# Altium schematic sheet stm32.SchDoc
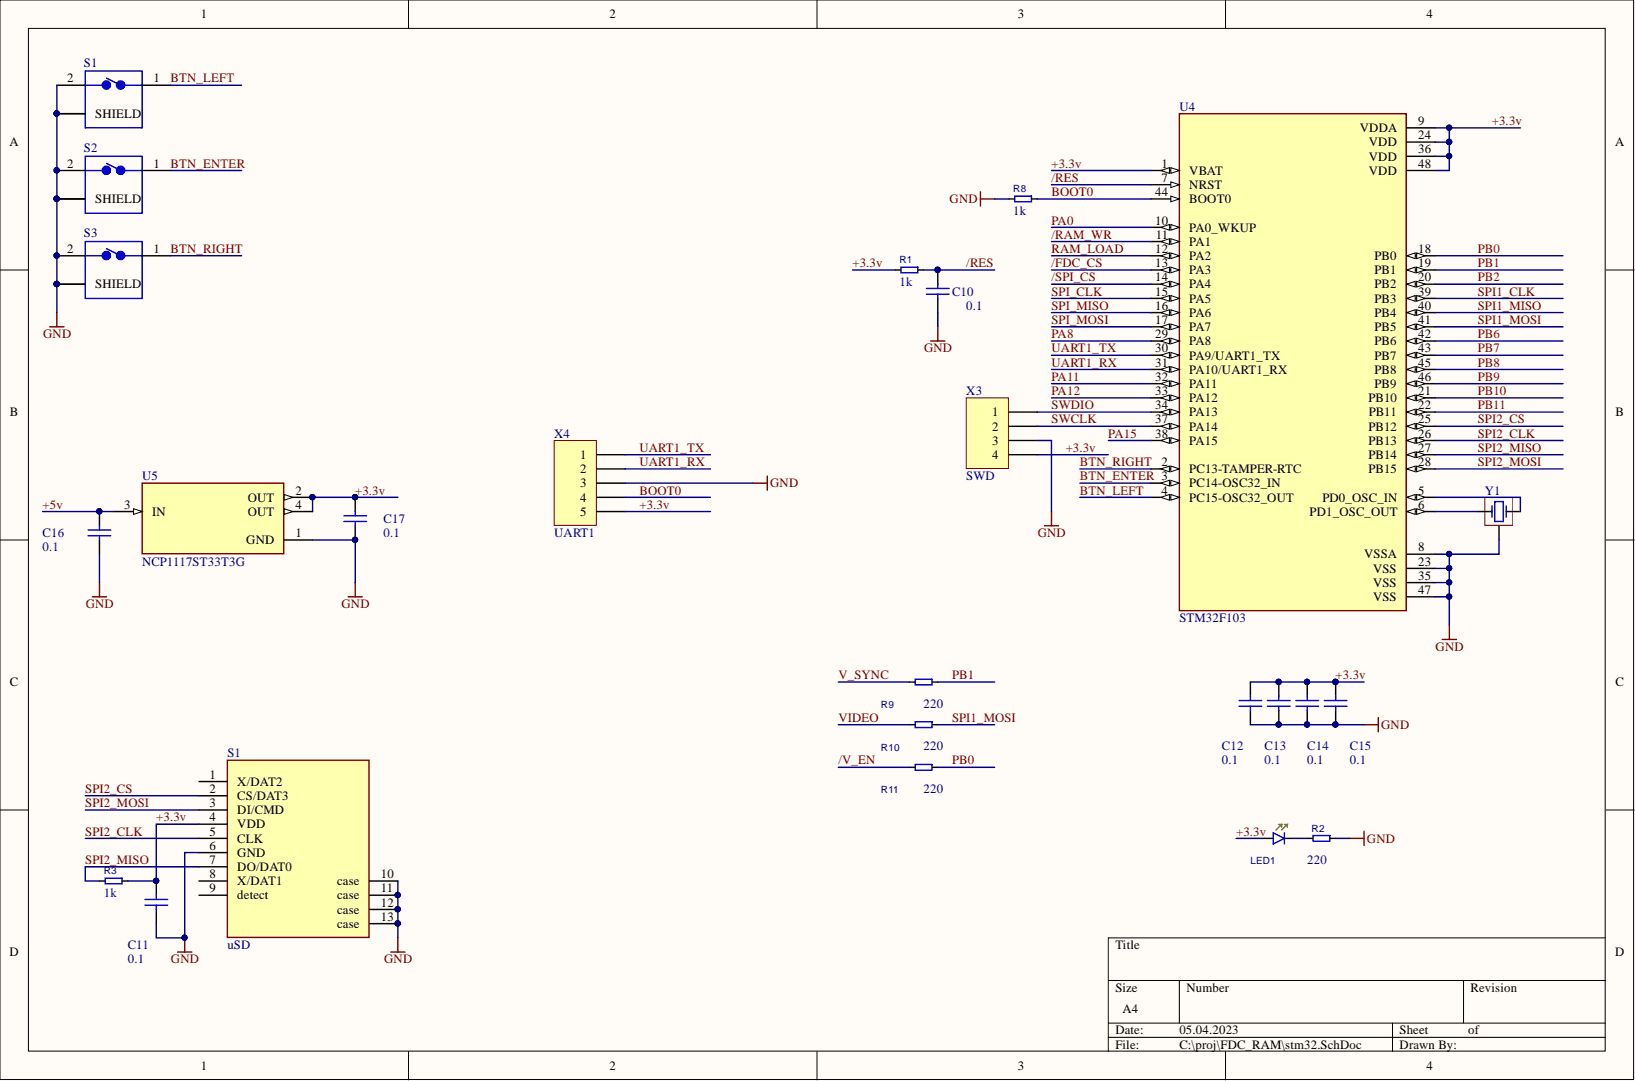
[diagram p0: stm32.SchDoc]

## Parts
C10  value 0.1  [0603_cap]  (hidden comment: CAP_0.1uF_0603)
C11  value 0.1  [0603_cap]  (hidden comment: CAP_0.1uF_0603)
C12  value 0.1  [0603_cap]  (hidden comment: CAP_0.1uF_0603)
C13  value 0.1  [0603_cap]  (hidden comment: CAP_0.1uF_0603)
C14  value 0.1  [0603_cap]  (hidden comment: CAP_0.1uF_0603)
C15  value 0.1  [0603_cap]  (hidden comment: CAP_0.1uF_0603)
C16  value 0.1  [0603_cap]  (hidden comment: CAP_0.1uF_0603)
C17  value 0.1  [0603_cap]  (hidden comment: CAP_0.1uF_0603)
LED1  LED-GREEN-0603  [0603_LEDG]  (value not drawn)
R1  1k  [0603_RESISTOR]
R2  220  [0603_RESISTOR]
R3  1k  [0603_RESISTOR]
R8  1k  [0603_RESISTOR]
R9  220  [0603_RESISTOR]  (value not drawn)
R10  220  [0603_RESISTOR]
R11  220  [0603_RESISTOR]
S1  uSD  [SD_CARD - SUNROM]
S1  2-1825027-0  [TE_2-1825027-0]  (value not drawn)
S2  2-1825027-0  [TE_2-1825027-0]  (value not drawn)
S3  2-1825027-0  [TE_2-1825027-0]  (value not drawn)
U4  STM32F103  [QFP50P900X900X160-48N]
U5  NCP1117ST33T3G  [SOT230P700X180-4N]
X3  SWD  [HDR1X4]
X4  UART1  [HDR1X5]
Y1  CRYSTAL-16.0MHz  [CSTCE16M0V53-R0]  (value not drawn)

## Nets
GND: C10.1 C11.1 C12.1 C13.1 C14.1 C15.1 C16.1 C17.1 R2.2 R8.1 S1.2 S1.6(GND) S1.10(case) S1.11(case) S1.12(case) S1.13(case) S1.S1(SHIELD) S1.S2 S2.2 S2.S1(SHIELD) S2.S2 S3.2 S3.S1(SHIELD) S3.S2 U4.8(VSSA) U4.23(VSS) U4.35(VSS) U4.47(VSS) U5.1(GND) X3.3 X4.3 Y1.2
+3.3v: C11.2 C12.2 C13.2 C14.2 C15.2 C17.2 LED1.1 R1.1 R3.2 S1.4(VDD) U4.1(VBAT) U4.9(VDDA) U4.24(VDD) U4.36(VDD) U4.48(VDD) U5.2(OUT) U5.4(OUT) X3.4 X4.5
+5v: C16.2 U5.3(IN)
/FDC_CS: U4.13(PA3)
/RAM_WR: U4.11(PA1)
/RES: C10.2 R1.2 U4.7(NRST)
/SPI_CS: U4.14(PA4)
/V_EN: R11.1
BOOT0: R8.2 U4.44(BOOT0) X4.4
BTN_ENTER: S2.1 U4.3(PC14-OSC32_IN)
BTN_LEFT: S1.1 U4.4(PC15-OSC32_OUT)
BTN_RIGHT: S3.1 U4.2(PC13-TAMPER-RTC)
PA0: U4.10(PA0_WKUP)
PA8: U4.29(PA8)
PA11: U4.32(PA11)
PA12: U4.33(PA12)
PA15: U4.38(PA15)
PB0: R11.2 U4.18(PB0)
PB1: R9.2 U4.19(PB1)
PB2: U4.20(PB2)
PB6: U4.42(PB6)
PB7: U4.43(PB7)
PB8: U4.45(PB8)
PB9: U4.46(PB9)
PB10: U4.21(PB10)
PB11: U4.22(PB11)
RAM_LOAD: U4.12(PA2)
SPI1_CLK: U4.39(PB3)
SPI1_MISO: U4.40(PB4)
SPI1_MOSI: R10.2 U4.41(PB5)
SPI2_CLK: S1.5(CLK) U4.26(PB13)
SPI2_CS: S1.2 U4.25(PB12)
SPI2_MISO: R3.1 S1.7(DO/DAT0) U4.27(PB14)
SPI2_MOSI: S1.3(DI/CMD) U4.28(PB15)
SPI_CLK: U4.15(PA5)
SPI_MISO: U4.16(PA6)
SPI_MOSI: U4.17(PA7)
SWCLK: U4.37(PA14) X3.2
SWDIO: U4.34(PA13) X3.1
UART1_RX: U4.31(PA10/UART1_RX) X4.2
UART1_TX: U4.30(PA9/UART1_TX) X4.1
V_SYNC: R9.1
VIDEO: R10.1
NetLED1_2: LED1.2 R2.1
NetU4_5: U4.5(PD0_OSC_IN) Y1.1
NetU4_6: U4.6(PD1_OSC_OUT) Y1.3

Not connected: S1 8(X/DAT1) 9(detect)

## Library data of the parts
C10-C17 = CAP_0.1uF_0603: CAP 0.1uF 63V CERAMIC X7R 0603; Manufacturer: Kemet; PartNumber: C0603C104K3RACTU; Component Kind: Standard; Datasheet link: http://www.kemet.com/kemet/web/homepage/kechome.nsf/vapubfilesname/F3102TA.pdf/$file/F3102TA.pdf; DigiKeyPartNumber: 399-1281-2-ND; Manufacturer URL: http://www.kemet.com/; RoHS: 1; Library Name: sunrise.SchLib
LED1 = LED-GREEN-0603: LED green 0603; Manufacturer: Osram; Manufacturer Part Number: LG T670-K1L2-1; Color: GREEN; Component Kind: Standard; Library Name: sunrise.SchLib
R1 R3 R8 = R-1k-0603: Resistor 1k 0603; Manufacturer: Generic; Rating: 63mW; Tolerance: 1%; Component Kind: Standard; value: 1k; Library Name: sunrise.SchLib
R2 R9-R11 = R-220-0603: Resistor 220 0603; Manufacturer: Generic; Rating: 63mW; Tolerance: 1%; Component Kind: Standard; value: 220; Library Name: sunrise.SchLib
S1 = uSD_card: uSD card socket; MF: Texas Instruments; MP: TXS0108E; Availability: In Stock; Check_prices: https://www.snapeda.com/parts/TXS0108E/Texas+Instruments/view-part/?ref=eda; Component Kind: Standard; Package: None; Price: None; Purchase-URL: https://pricing.snapeda.com/search/part/TXS0108E/?ref=eda; SnapEDA_Link: https://www.snapeda.com/parts/TXS0108E/Texas+Instruments/view-part/?ref=snap; Library Name: sunrise.SchLib
S1-S3 = 2-1825027-0: Switch Tactile OFF _ON_ SPST Round Button PC Pins 0.05A 24VDC 1.57N Thru-Hole Loose Piece; MF: TE Connectivity; Availability: Unavailable; Component Kind: Standard; EU_RoHS_Compliance: Compliant; MP: 2-1825027-0; Package: None; Price: None; SnapEDA_Link: https://www.snapeda.com/parts/2-1825027-0/TE%20Connectivity%20ALCOSWITCH%20Switches/view-part/236241/?ref=snap; TE_purchase_URL: https://www.te.com/usa-en/product-2-1825027-0.html?te_bu=Cor&te_type=disp&te_campaign=seda_glo_cor-seda-global-disp-prtnr-fy19-seda-model-bom-cta_sma-317_1&elqCampaignId=32493; Library Name: sunrise.SchLib
U4 = IC_STM32F103C8T6: STM32F103, STM32F303, GD32F103, LQFP-48; Availability: Unavailable; Component Kind: Standard; MP: STM32F103C8T6; Package: None; Price: None; Library Name: sunrise.SchLib
U5 = NCP1117ST33T3G: Linear Voltage Regulator IC 1 Output 1A SOT-223; MF: ON Semiconductor; Availability: Unavailable; Component Kind: Standard; MP: NCP1117ST33T3G; Package: TO-261-4 HTC Korea; Price: None; SnapEDA_Link: https://www.snapeda.com/parts/NCP1117ST33T3G/ON%20Semiconductor/view-part/802519/?ref=snap; Library Name: fdc_ram.SchLib
X3 = Header 4: Header, 4-Pin; Component Kind: Standard; LatestRevisionDate: 17-Jul-2002; LatestRevisionNote: Re-released for DXP Platform.; Library Name: Miscellaneous Connectors.IntLib; Signal Integrity: Connector; Publisher: Altium Limited
X4 = Header 5: Header, 5-Pin; Component Kind: Standard; LatestRevisionDate: 17-Jul-2002; LatestRevisionNote: Re-released for DXP Platform.; Library Name: Miscellaneous Connectors.IntLib; Signal Integrity: Connector; Publisher: Altium Limited
Y1 = CRYSTAL-16.0MHz: Crystal Oscillator; Manufacturer: SaRonix-eCERA; PartNumber: FL1200065; Component Kind: Standard; Manufacturer URL: http://www.pericom.com; Simulation: XTAL; Datasheet link: Z:\Engineering\Projects\Design\Past Projects\2009 Eng Projects\50004514_Mytraker\6.DataSheets\Crystal\Saronix Ecera; Library Name: sunrise.SchLib
